# Altium schematic sheet ppg_imu.SchDoc
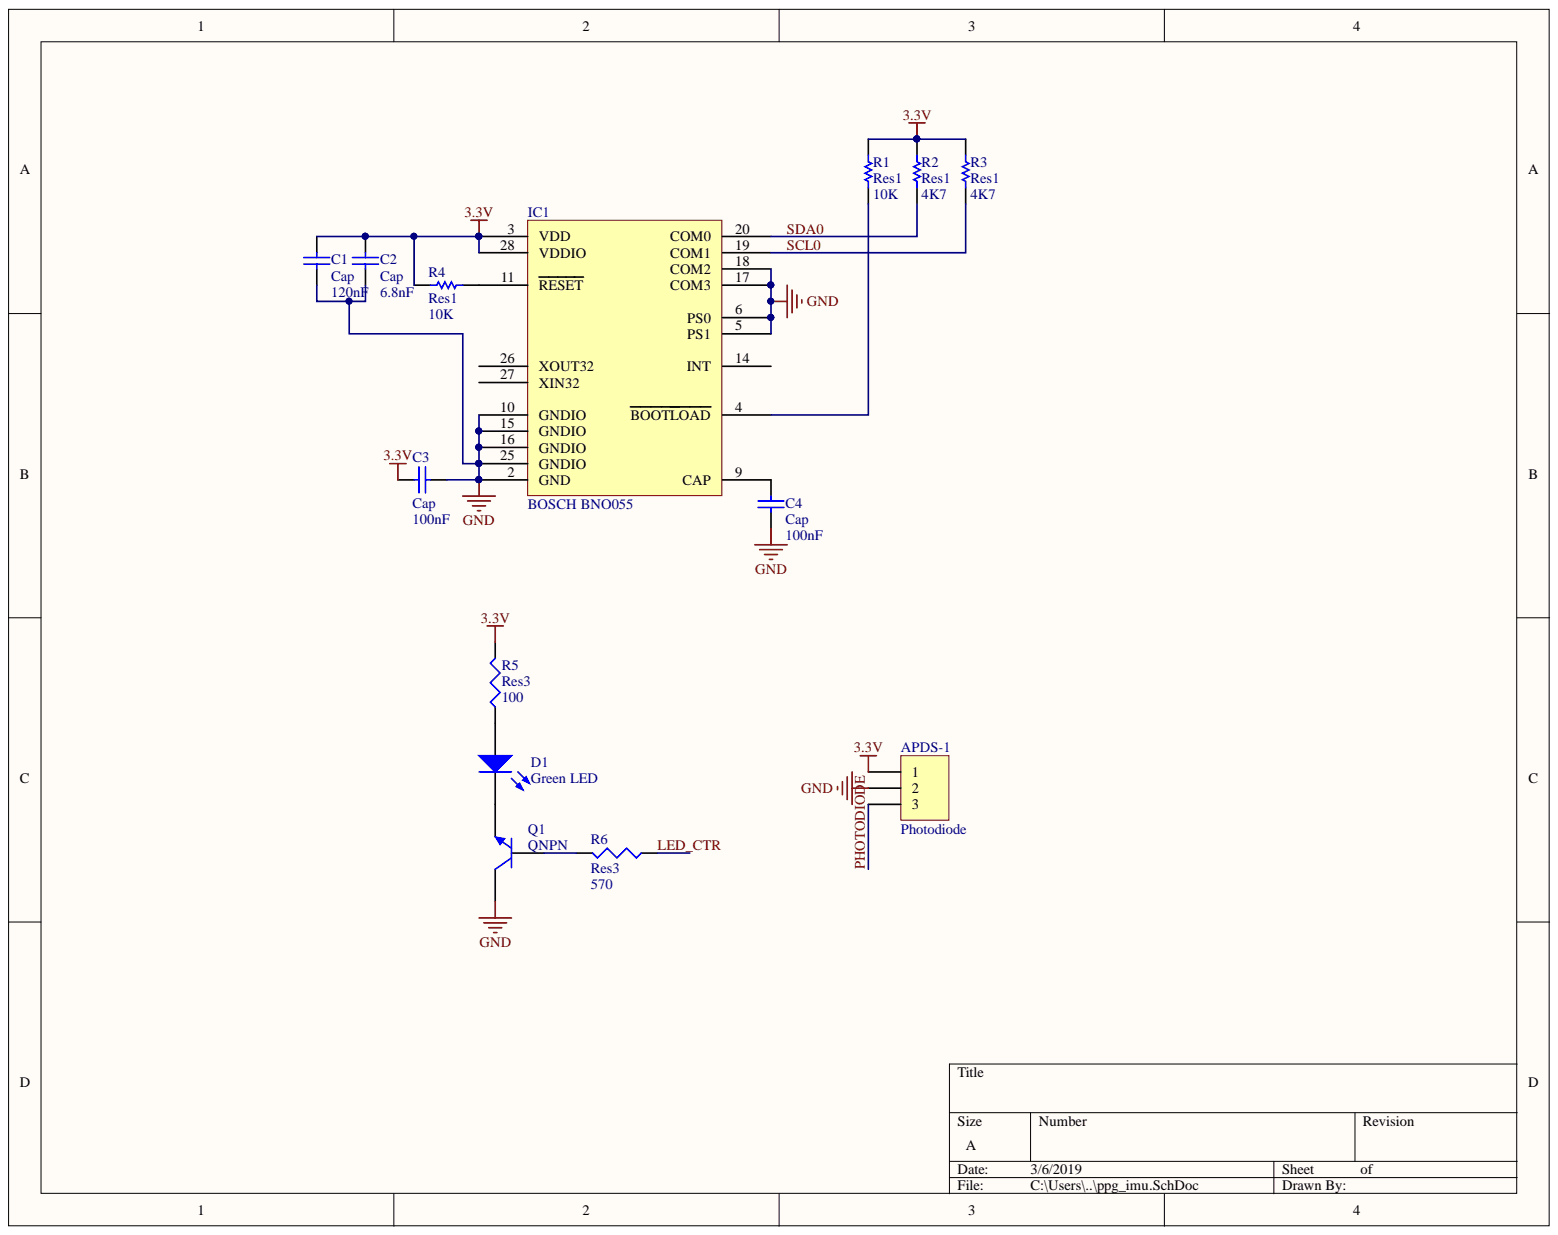
[diagram p0: ppg_imu.SchDoc]

## Parts
APDS-1  Photodiode  [APDS-9008]
C1  Cap  value 120nF  [CAP0603]
C2  Cap  value 6.8nF  [CAP0603]
C3  Cap  value 100nF  [CAP0603]
C4  Cap  value 100nF  [CAP0603]
D1  Green LED  [3.2X1.6X1.1]
IC1  BOSCH BNO055  [BOSCH LGA-28 5.2x3.8MM]
Q1  QNPN  [SOT-23B_N]
R1  Res1  value 10K  [RES0603]
R2  Res1  value 4K7  [RES0603]
R3  Res1  value 4K7  [RES0603]
R4  Res1  value 10K  [RES0603]
R5  Res3  value 100  [J1-0603]
R6  Res3  value 570  [J1-0603]

## Nets
3.3V: APDS-1.1 C1.2 C2.2 C3.1 IC1.3(VDD) IC1.28(VDDIO) R1.1 R2.1 R3.1 R4.1 R5.2
GND: APDS-1.2 C1.1 C2.1 C3.2 C4.1 IC1.2(GND) IC1.5(PS1) IC1.6(PS0) IC1.10(GNDIO) IC1.15(GNDIO) IC1.16(GNDIO) IC1.17(COM3) IC1.18(COM2) IC1.25(GNDIO) Q1.1
LED_CTR: R6.1
PHOTODIODE: APDS-1.3
SCL0: IC1.19(COM1) R3.2
SDA0: IC1.20(COM0) R2.2
NetC4_2: C4.2 IC1.9(CAP)
NetD1_1: D1.1 R5.1
NetD1_2: D1.2 Q1.3
NetIC1_4: IC1.4(BOOTLOAD) R1.2
NetIC1_11: IC1.11(RESET) R4.2
NetQ1_2: Q1.2 R6.2

Not connected: IC1 14(INT) 26(XOUT32) 27(XIN32)

## Library data of the parts
APDS-1 = Header 3: Header, 3-Pin; Component Kind: Standard; LatestRevisionDate: 17-Jul-2002; LatestRevisionNote: Re-released for DXP Platform.; Library Name: Miscellaneous Connectors.IntLib; Signal Integrity: Connector; Publisher: Altium Limited
C1-C4 = Cap: Capacitor; Component Kind: Standard; LatestRevisionDate: 17-Jul-2002; LatestRevisionNote: Re-released for DXP Platform.; Library Name: Miscellaneous Devices.IntLib; PackageDescription: Radial Cap, Thru-Hole; 2 Leads; 0.3 in Pin Spacing; PackageReference: RAD-0.3; Published: 8-Jun-2000; Signal Integrity: Cap; Simulation: CAP; Publisher: Altium Limited
D1 = LED0: Typical INFRARED GaAs LED; Component Kind: Standard; LatestRevisionDate: 08-Jul-2005; LatestRevisionNote: Mech Layer 1 removed.; Library Name: Miscellaneous Devices.IntLib; PackageDescription: LED; 2 Leads; PackageReference: LED-0; Published: 8-Jun-2000; Simulation: LED0; Publisher: Altium Limited
Q1 = QNPN: NPN Bipolar Transistor; Component Kind: Standard; LatestRevisionDate: 29-May-2009; LatestRevisionNote: IPC-7351 Footprint Added.; Library Name: Miscellaneous Devices.IntLib; PackageDescription: 3-Pin SOT-23 Package 0.95 mm Pitch, 2.4 mm Lead Span; PackageReference: SOT-23B; PackageVersion: Aug-1999; Published: 8-Jun-2000; Signal Integrity: NPN; Simulation: QNPN; Publisher: Altium Limited
R1-R4 = Res1: Resistor; Component Kind: Standard; LatestRevisionDate: 17-Jul-2002; LatestRevisionNote: Re-released for DXP Platform.; Library Name: Miscellaneous Devices.IntLib; PackageDescription: Axial Device, Thru-Hole; 2 Leads; 0.3 in Pin Spacing; PackageReference: AXIAL-0.3; Published: 8-Jun-2000; Signal Integrity: Res; Simulation: RESISTOR; Publisher: Altium Limited
R5 R6 = Res3: Resistor; Component Kind: Standard; LatestRevisionDate: 29-May-2009; LatestRevisionNote: IPC-7351 Footprint Added.; Library Name: Miscellaneous Devices.IntLib; PackageDescription: Chip Resistor; PackageReference: J1-0603; Published: 8-Jun-2000; Signal Integrity: Res; Simulation: RESISTOR; Publisher: Altium Limited
IC1 = BOSCH BNO055: Component Kind: Standard; Library Name: Motion Sensor.SchLib
